# Altium schematic sheet 5V_CoolantEfuses.SchDoc(5V_CoolantEfuses)
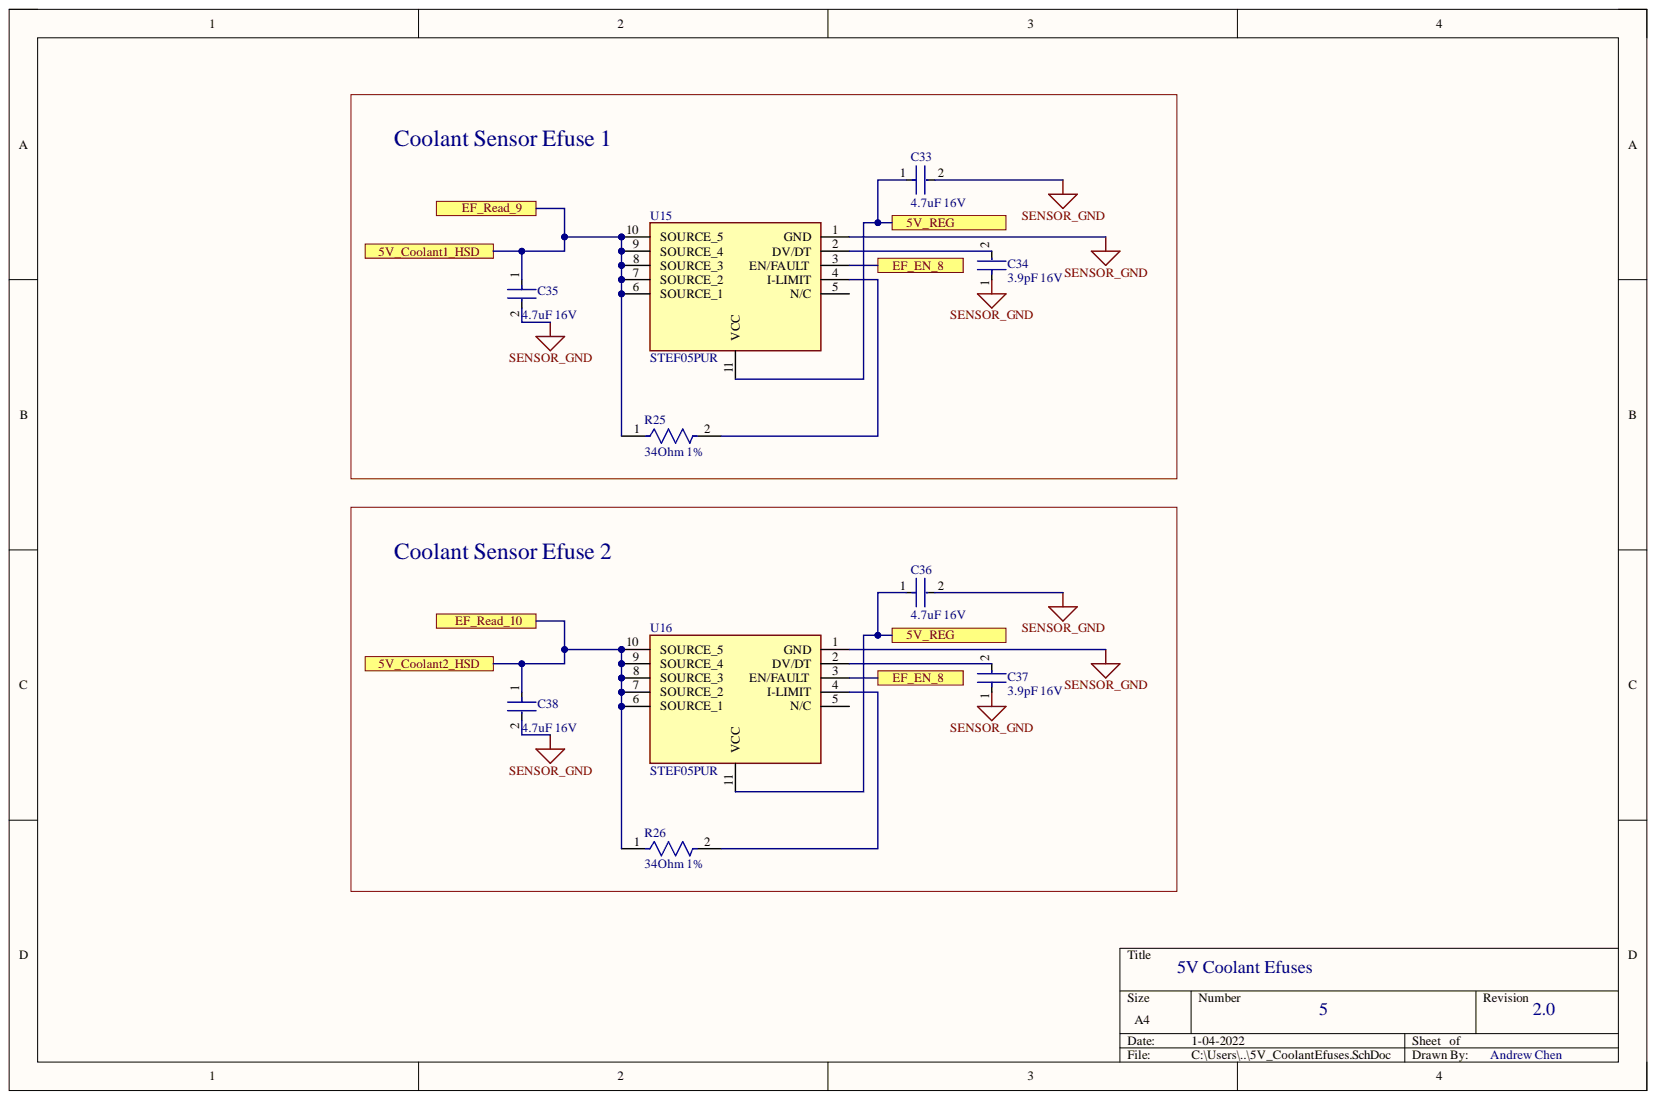
[diagram p0: 5V_CoolantEfuses.SchDoc]

## Parts
C33  4.7uF 16V  Capacitance: 4.7uF  Voltage Rating: 16V  [FP-CL31-IPC_A]
C34  3.9pF 16V  [FP-CL31-IPC_A]
C35  4.7uF 16V  Capacitance: 4.7uF  Voltage Rating: 16V  [FP-CL31-IPC_A]
C36  4.7uF 16V  Capacitance: 4.7uF  Voltage Rating: 16V  [FP-CL31-IPC_A]
C37  3.9pF 16V  [FP-CL31-IPC_A]
C38  4.7uF 16V  Capacitance: 4.7uF  Voltage Rating: 16V  [FP-CL31-IPC_A]
R25  34Ohm 1%  Tolerance: 1%  [FP-CRCW0603-e3-IPC_B]
R26  34Ohm 1%  Tolerance: 1%  [FP-CRCW0603-e3-IPC_B]
U15  STEF05PUR  [SON50P300X300X100-11N-D]
U16  STEF05PUR  [SON50P300X300X100-11N-D]

## Ports
5V_Coolant1_HSD, 5V_Coolant2_HSD, 5V_REG, EF_EN_8, EF_Read_9, EF_Read_10

## Nets
SENSOR_GND: C33.2 C34.1 C35.2 C36.2 C37.1 C38.2 U15.1(GND) U16.1(GND)
5V_Coolant1_HSD: C35.1 R25.1 U15.6(SOURCE_1) U15.7(SOURCE_2) U15.8(SOURCE_3) U15.9(SOURCE_4) U15.10(SOURCE_5) ; port 5V_Coolant1_HSD, port EF_Read_9
5V_Coolant2_HSD: C38.1 R26.1 U16.6(SOURCE_1) U16.7(SOURCE_2) U16.8(SOURCE_3) U16.9(SOURCE_4) U16.10(SOURCE_5) ; port 5V_Coolant2_HSD, port EF_Read_10
5V_REG: C33.1 C36.1 U15.11(VCC) U16.11(VCC) ; port 5V_REG
EF_EN_8: U15.3(EN/FAULT) U16.3(EN/FAULT) ; port EF_EN_8
NetC34_2: C34.2 U15.2(DV/DT)
NetC37_2: C37.2 U16.2(DV/DT)
NetR25_2: R25.2 U15.4(I-LIMIT)
NetR26_2: R26.2 U16.4(I-LIMIT)

Not connected: U15 5(N/C); U16 5(N/C)

## Library data of the parts
C33-C38 = CMP-13271-002699-1: Cap Ceramic 4.7uF 16V X7R ±10% SMD 1206 +125°C Embossed T/R; Case Code (Imperial): 1206; Case Code (Metric): 3216; Case/Package: 1206; Component Kind: Standard; Depth: 1.6mm; Dielectric: X7R; Height: 1.6mm; Length: 0.126inch; Library Name: Altium Content Vault; Max Operating Temperature: 125°C; Min Operating Temperature: -55°C; Mount: Surface Mount; Package Quantity: 1; Packaging: Tape and Reel; Radiation Hardening: No; Thickness: 0.071inch; Tolerance: 10%; Width: 0.063inch
  C34 C37: Capacitance: 4.7uF; Voltage Rating: 16V
R25 R26 = CMP-02407-002818-1: RES Thick Film, 18Ω, 1%, 0.1W, 100ppm/°C, 0603; Max Power Dissipation: 100mW; Resistance: 18R; Voltage Rating: 75V; Case Code (Imperial): 0603; Case Code (Metric): 1608; Case/Package: 0603; Component Kind: Standard; Composition: Thick Film; Contact Plating: Tin; Depth: 0.85mm; Features: Automotive AEC-Q200; Height: 0.45mm; Lead Free: Lead Free; Length: 1.55mm; Library Name: Altium Content Vault; Max Operating Temperature: 155°C; Military Standard: Not; Min Operating Temperature: -55°C; Mount: Surface Mount; Number of Pins: 2; Number of Terminations: 2; Package Quantity: 10; Packaging: Tape and Reel; Power Rating: 100mW; Radiation Hardening: No; Temperature Coefficient: 100ppm/°C; Termination: SMD/SMT; Voltage Rating (DC): 75V; Weight: 7.1E-05oz; Width: 0.033inch
U15 U16 = STEF12EPUR: Integrated Circuit; Manufacturer_Name: STMicroelectronics; Arrow Part Number: STEF12EPUR; Arrow Price/Stock: https://www.arrow.com/en/products/stef12epur/stmicroelectronics; Component Kind: Standard; Datasheet Link: https://www.arrow.com/en/products/stef12epur/stmicroelectronics; Height: 1mm; Manufacturer_Part_Number: STEF12EPUR; Mouser Part Number: 511-STEF12EPUR; Mouser Price/Stock: https://www.mouser.co.uk/ProductDetail/STMicroelectronics/STEF12EPUR?qs=mKNKSX85ZJfL9n%252BG1BPmuw%3D%3D
